# Altium schematic sheet KS_ESP32_CAM.SchDoc(KS_ESP32_CAM)
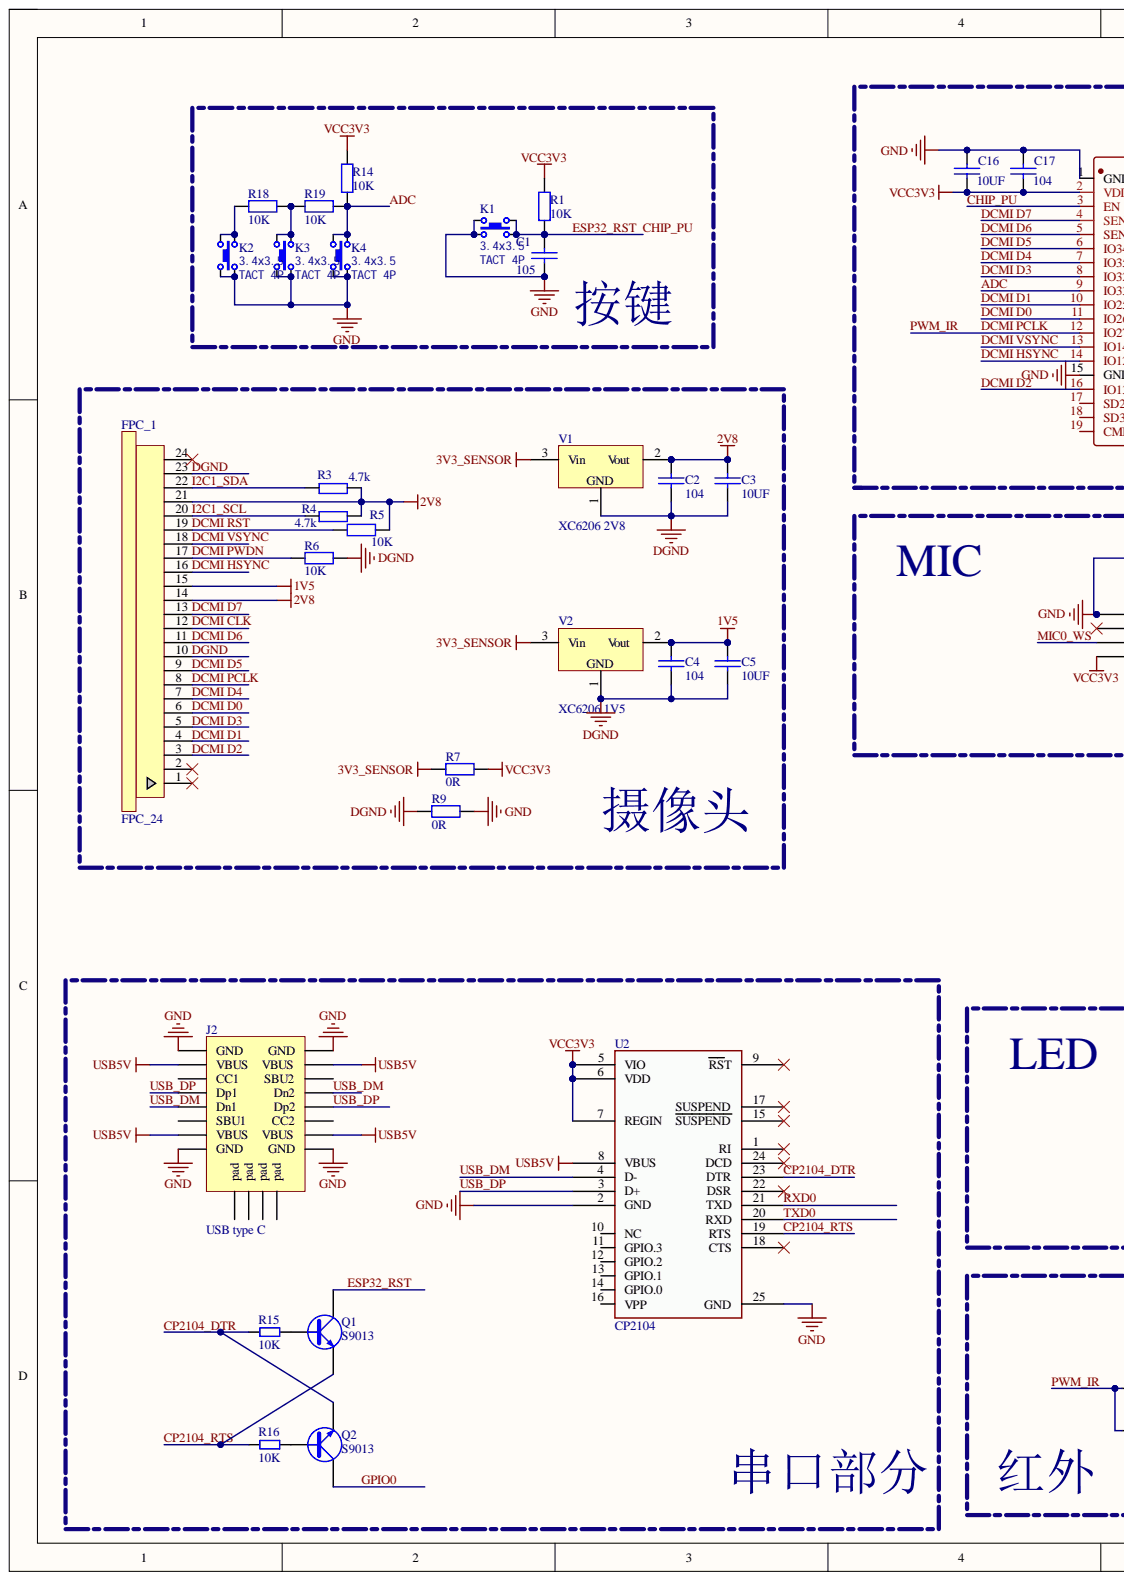
[diagram p0: KS_ESP32_CAM.SchDoc, left]
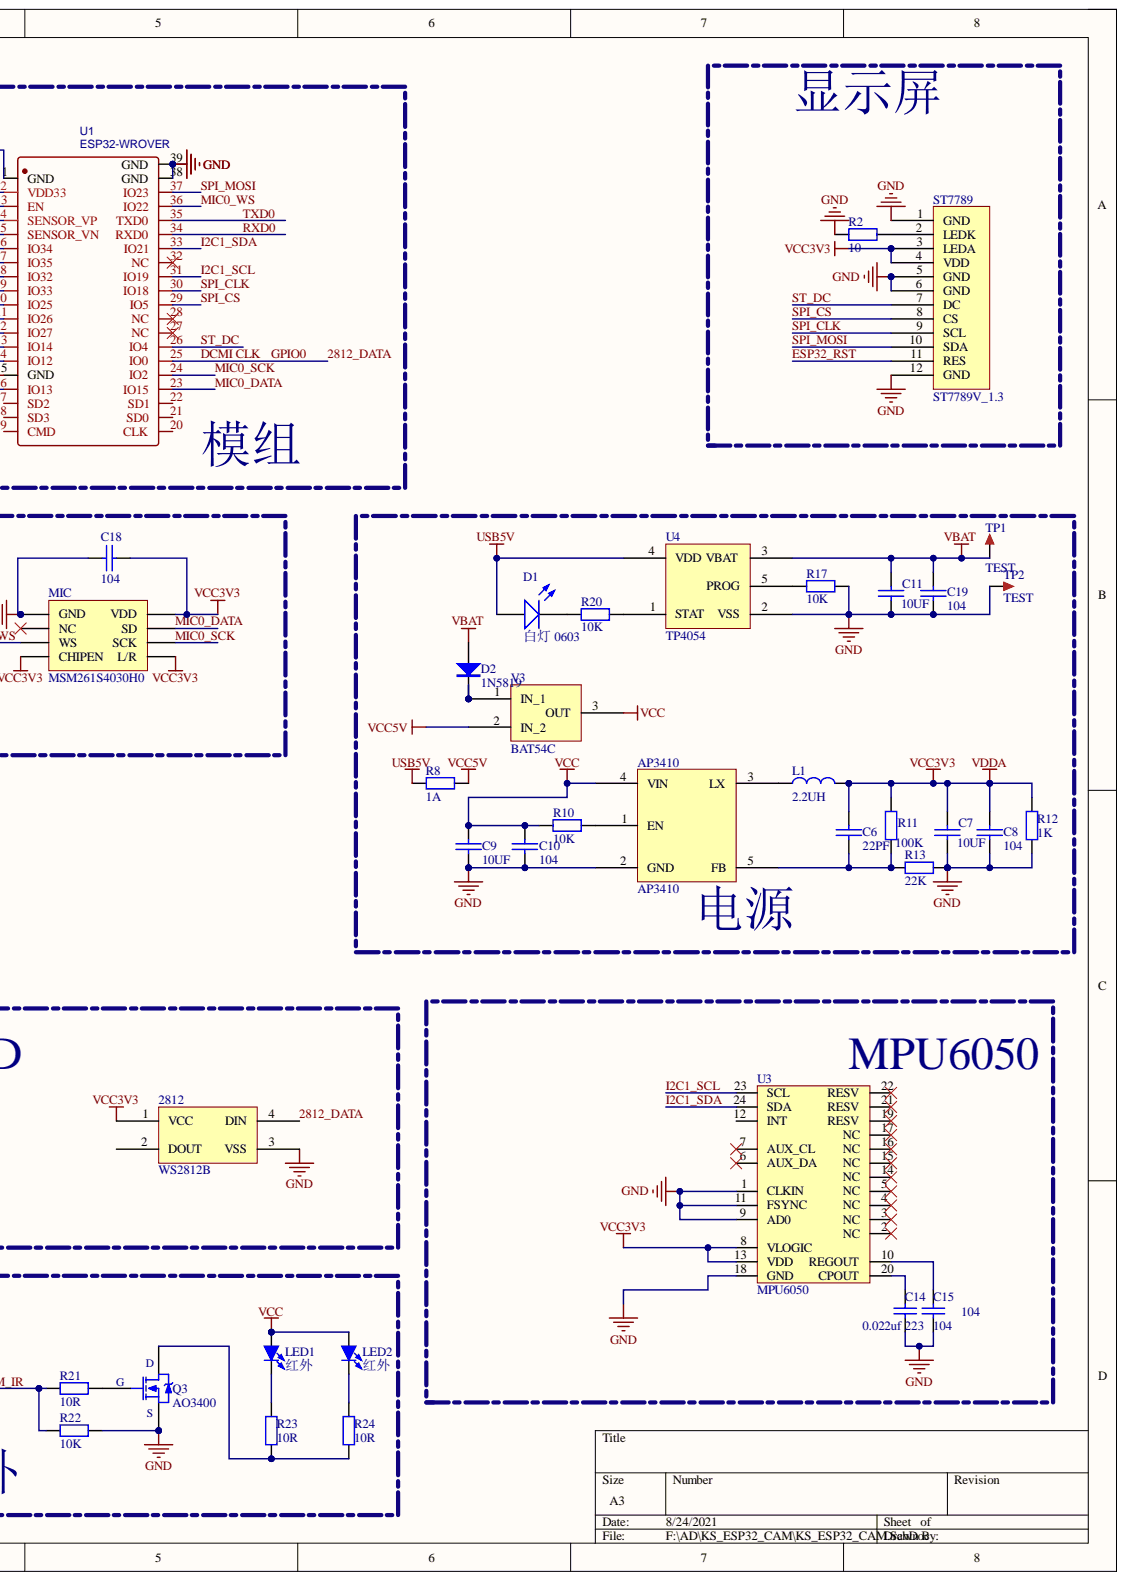
[diagram p1: KS_ESP32_CAM.SchDoc, right]

## Parts
2812  WS2812B  [LED_3528_RGB]
AP3410  AP3410  [SOT23-5N]
C1  105  [0603_C]
C2  104  [0603_C]
C3  10UF  [0603_C]
C4  104  [0603_C]
C5  10UF  [0603_C]
C6  22PF  [LC-0603_C]
C7  10UF  [LC-0603_C]
C8  104  [LC-0603_C]
C9  10UF  [LC-0603_C]
C10  104  [LC-0603_C]
C11  10UF  [LC-0603_C]
C14  0.022uf  value 223  [LC-0603_C]
C15  104  [LC-0603_C]
C16  10UF  [LC-0603_C]
C17  104  [LC-0603_C]
C18  104  [LC-0603_C]
C19  104  [LC-0603_C]
D1  白灯 0603  Package: 0603  [0603_LED_S1]
D2  1N5819  [SOD-323]
FPC_1  FPC_24  [FPC_24]
J2  USB type C  [USB Type-C-16Pin_A]
K1  TACT 4P  value 3.4x3.5  [侧边按钮]
K2  TACT 4P  value 3.4x3.5  [侧边按钮]
K3  TACT 4P  value 3.4x3.5  [侧边按钮]
K4  TACT 4P  value 3.4x3.5  [侧边按钮]
L1  2.2UH  [L-SMD-2.5*2.0*1.2MM]
LED1  红外  [LED_3528_RED]
LED2  红外  [LED_3528_RED]
MIC  MSM261S4030H0  [MSM261S4030H0]
Q1  S9013  [SOT23-3N]
Q2  S9013  [SOT23-3N]
Q3  AO3400  [SOT-23(SOT-23-3)]
R1  10K  [0603_R]
R2  value 10  [0603_R]  (hidden comment: 10R)
R3  4.7k  [LC-0603_R]
R4  4.7k  [LC-0603_R]
R5  10K  [0603_R]
R6  10K  [0603_R]
R7  0R  [0603_R]
R8  1A  [0805_R]
R9  0R  [0603_R]
R10  10K  [0603_R]
R11  100K  [0603_R]
R12  1K  [0603_R]
R13  22K  [0603_R]
R14  10K  [0603_R]
R15  10K  [0603_R]
R16  10K  [0603_R]
R17  10K  [0603_R]
R18  10K  [0603_R]
R19  10K  [0603_R]
R20  10K  [0603_R]
R21  10R  [0603_R]
R22  10K  [0603_R]
R23  10R  [0603_R]
R24  10R  [0603_R]
ST7789  ST7789V_1.3  [IPS_1.54]
TP1  TEST  [TEST 1.6]
TP2  TEST  [TEST 1.6]
U1  ESP32-WROVER  [ESP32_WROVER]
U2  CP2104  [QFN24 4x4_N]
U3  MPU6050  [QFN24 4x4_N]
U4  TP4054  [SOT23-5N]
V1  XC6206 2V8  [SOT23-3N]
V2  XC6206 1V5  [SOT23-3N]
V3  BAT54C  [SOT23-3N]

## Nets
1V5: C4.1 C5.1 FPC_1.15 V2.2
2V8: C2.1 C3.1 FPC_1.14 FPC_1.21 R3.2 R4.2 R5.2 V1.2
3V3_SENSOR: R7.2 V1.3 V2.3
GND: 2812.3(VSS) AP3410.2(GND) C1.1 C7.1 C8.1 C9.1 C10.1 C11.1 C14.1 C15.1 C16.2 C17.2 C18.1 C19.1 J2.A1(GND) J2.A12(GND) J2.B1(GND) J2.B12(GND) K1.1 K1.3 K2.1 K2.3 K3.1 K3.3 K4.1 K4.3 MIC.1(GND) Q3.2 R2.2 R9.1 R12.2 R13.1 R17.1 R22.1 ST7789.1(GND) ST7789.5(GND) ST7789.6(GND) ST7789.12(GND) TP2.1 U1.1(GND) U1.15(GND) U1.38(GND) U1.39(GND) U2.2(GND) U2.25(GND) U3.1(CLKIN) U3.9(AD0) U3.11(FSYNC) U3.18(GND) U4.2(VSS)
USB5V: D1.A J2.A4(VBUS) J2.A9(VBUS) J2.B4(VBUS) J2.B9(VBUS) R8.2 U2.8(VBUS) U4.4(VDD)
VBAT: C11.2 C19.2 D2.1 TP1.1 U4.3(VBAT)
VCC: AP3410.4(VIN) C9.2 C10.2 LED1.1 LED2.1 R10.2 V3.3
VCC3V3: 2812.1(VCC) C6.2 C7.2 C8.2 C16.1 C17.1 C18.2 L1.1 MIC.4(CHIPEN) MIC.5(L/R) MIC.8(VDD) R1.1 R7.1 R11.1 R12.1 R14.1 ST7789.3(LEDA) ST7789.4(VDD) U1.2(VDD33) U2.5(VIO) U2.6(VDD) U2.7(REGIN) U3.8(VLOGIC) U3.13(VDD)
VCC5V: R8.1 V3.2
2812_DATA: 2812.4(DIN) FPC_1.12 Q2.3 U1.25(IO0)
ADC: K4.2 K4.4 R14.2 R19.2 U1.9(IO33)
CHIP_PU: C1.2 K1.2 K1.4 Q1.3 R1.2 ST7789.11(RES) U1.3(EN)
CP2104_DTR: Q2.2 R15.1 U2.23(DTR)
CP2104_RTS: Q1.2 R16.1 U2.19(RTS)
DCMI D0: FPC_1.6 U1.11(IO26)
DCMI D1: FPC_1.4 U1.10(IO25)
DCMI D2: FPC_1.3 U1.16(IO13)
DCMI D3: FPC_1.5 U1.8(IO32)
DCMI D4: FPC_1.7 U1.7(IO35)
DCMI D5: FPC_1.9 U1.6(IO34)
DCMI D6: FPC_1.11 U1.5(SENSOR_VN)
DCMI D7: FPC_1.13 U1.4(SENSOR_VP)
DCMI HSYNC: FPC_1.16 U1.14(IO12)
DCMI PCLK: FPC_1.8 R21.2 R22.2 U1.12(IO27)
DCMI PWDN: FPC_1.17 R6.1
DCMI RST: FPC_1.19 R5.1
DCMI VSYNC: FPC_1.18 U1.13(IO14)
DGND: C2.2 C3.2 C4.2 C5.2 FPC_1.10 FPC_1.23 R6.2 R9.2 V1.1 V2.1
I2C1_SCL: FPC_1.20 R4.1 U1.31(IO19) U3.23(SCL)
I2C1_SDA: FPC_1.22 R3.1 U1.33(IO21) U3.24(SDA)
MIC0_DATA: MIC.7(SD) U1.23(IO15)
MIC0_SCK: MIC.6(SCK) U1.24(IO2)
MIC0_WS: MIC.3(WS) U1.36(IO22)
RXD0: U1.34(RXD0) U2.21(TXD)
SPI_CLK: ST7789.9(SCL) U1.30(IO18)
SPI_CS: ST7789.8(CS) U1.29(IO5)
SPI_MOSI: ST7789.10(SDA) U1.37(IO23)
ST_DC: ST7789.7(DC) U1.26(IO4)
TXD0: U1.35(TXD0) U2.20(RXD)
USB_DM: J2.A7(Dn1) J2.B7(Dn2) U2.4(D-)
USB_DP: J2.A6(Dp1) J2.B6(Dp2) U2.3(D+)
NetAP3410_1: AP3410.1(EN) R10.1
NetAP3410_3: AP3410.3(LX) L1.2
NetAP3410_5: AP3410.5(FB) C6.1 R11.2 R13.2
NetC14_2: C14.2 U3.20(CPOUT)
NetC15_2: C15.2 U3.10(REGOUT)
NetD1_K: D1.K R20.2
NetD2_2: D2.2 V3.1
NetK2_2: K2.2 K2.4 R18.1
NetK3_2: K3.2 K3.4 R18.2 R19.1
NetLED1_2: LED1.2 R23.1
NetLED2_2: LED2.2 R24.1
NetQ1_1: Q1.1 R15.2
NetQ2_1: Q2.1 R16.2
NetQ3_1: Q3.1 R21.1
NetQ3_3: Q3.3 R23.2 R24.2
NetR2_1: R2.1 ST7789.2(LEDK)
NetR17_2: R17.2 U4.5(PROG)
NetR20_1: R20.1 U4.1(STAT)

Not connected: 2812 2(DOUT); FPC_1 1 2 24; J2 0(pad) A5(CC1) A8(SBU1) B5(CC2) B8(SBU2); MIC 2(NC); U1 17(SD2) 18(SD3) 19(CMD) 20(CLK) 21(SD0) 22(SD1) 27(NC) 28(NC) 32(NC); U2 1(RI) 9(RST) 10(NC) 11(GPIO.3) 12(GPIO.2) 13(GPIO.1) 14(GPIO.0) 15(SUSPEND) 16(VPP) 17(SUSPEND) 18(CTS) 22(DSR) 24(DCD); U3 2(NC) 3(NC) 4(NC) 5(NC) 6(AUX_DA) 7(AUX_CL) 12(INT) 14(NC) 15(NC) 16(NC) 17(NC) 19(RESV) 21(RESV) 22(RESV)

## Library data of the parts
C1 = C: Capacitor; Component Kind: Standard; Library Name: Tribrer_GENERAL.SchLib
C2-C5 C18 = CAP: Component Kind: Standard; Library Name: XKZ.SchLib
C6-C11 C16 C17 C19 = cap: Component Kind: Standard; Library Name: 自创.SchLib
C14 C15 = Cap: Capacitor; Component Kind: Standard; LatestRevisionDate: 17-Jul-2002; LatestRevisionNote: Re-released for DXP Platform.; Library Name: Miscellaneous Devices.IntLib; PackageDescription: Radial Cap, Thru-Hole; 2 Leads; 0.3 in Pin Spacing; PackageReference: RAD-0.3; Published: 8-Jun-2000; Signal Integrity: Cap; Simulation: CAP; Publisher: Altium Limited
  C15: Value: 104
D1 = 0603 白灯: 发光二极管; Component Kind: Standard; ComponentLink1Description: 供应商链接; ComponentLink1URL: http://www.szlcsc.com/product/details_2663.html; Library Name: Miscellaneous Devices LC.IntLib; Supplier: LC; SuppliersPartNumber: C2290
D2 = 1N5819: 肖特基二极管; 属性: 40V/2A; 版权归属: 源创客; BOM名称: 肖特基二极管; Component Kind: Standard; Library Name: 8.1   - 二极管-整流桥.SchLib; 学习资源: www.ooocke.com
J2 = USB_C: Component Kind: Standard; Library Name: XKZ.SchLib
K1-K4 = ButtonTACT: Button; Component Kind: Standard; Library Name: KitSprout.IntLib; type: TACT 4P
L1 = L1: 电感; Component Kind: Standard; Library Name: XKZ.SchLib
LED1 LED2 = LED-3MM: 插件红外发射管3mm; BOM名称: 插件LED; 版权归属: 源创客; Component Kind: Standard; Library Name: 3D库.IntLib; 学习资源: www.ooocke.com
Q1 Q2 = Q S9013: Component Kind: Standard; Library Name: GENERAL.SchLib
Q3 = NPN DIAC: 贴片MOS管; Component Kind: Standard
R1 R5-R14 R17-R24 = RES: 普通电阻; Component Kind: Standard; Library Name: LIB_MANGO.IntLib
R2 = Res2: Resistor; Component Kind: Standard; LatestRevisionDate: 17-Jul-2002; LatestRevisionNote: Re-released for DXP Platform.; PackageReference: AXIAL-0.4; Published: 8-Jun-2000; Signal Integrity: Res; Simulation: RESISTOR; Library Name: XKZ.SchLib; Publisher: Altium Limited
R3 R4 = R_1: Component Kind: Standard; Library Name: Explorer STM32F407封装库.IntLib; value: 4.7K
R15 R16 = R_0Ω_0603: Component Kind: Standard; Library Name: Rtnzero_HW_Lib.IntLib
TP1 TP2 = TEST: 测试点; 版权归属: 源创客; BOM名称: 测试点; Component Kind: Standard; Library Name: 15.1 - 未分类器件.SchLib; 学习资源: www.ooocke.com
U1 = ESP32-WROVER-B: BOM_Manufacturer: Espressif Systems; BOM_Paste Type: expand; Contributor: LCSC; BOM_Manufacturer Part: ESP32-WROVER-B; BOM_Supplier: LCSC; BOM_Supplier Part: C503591; Component Kind: Standard; spicePre: U; spiceSymbolName: ESP32-WROVER-B
U3 = MPU6050: 9轴运动处理传感器; Component Kind: Standard; Library Name: Explorer STM32F407封装库.IntLib
U4 = MCP73831: 线性充电管理控制器; Component Kind: Standard; Library Name: TriggersLib.SchLib
V1 V2 = XC6206P332MR: 低压差线性稳压芯片; 版权归属: 源创客; BOM名称: 低压差线性稳压芯片; Component Kind: Standard; 学习资源: www.ooocke.com; Library Name: XKZ.SchLib
2812 = WS2812B: Component Kind: Standard; Library Name: XKZ.SchLib
AP3410 = AP3410: Component Kind: Standard; Library Name: XKZ.SchLib
FPC_1 = FPC_24: Component Kind: Standard; Library Name: XKZ.SchLib
MIC = MSM261S4030H0: Component Kind: Standard; Library Name: XKZ.SchLib
ST7789 = ST7789V_1.3: Component Kind: Standard; Library Name: XKZ.SchLib
U2 = CP2104: Component Kind: Standard; Library Name: LIB_MANGO.IntLib
V3 = BAT54C: Component Kind: Standard; Library Name: XKZ.SchLib
